# Altium schematic sheet Sheet1.SchDoc(Sheet1)
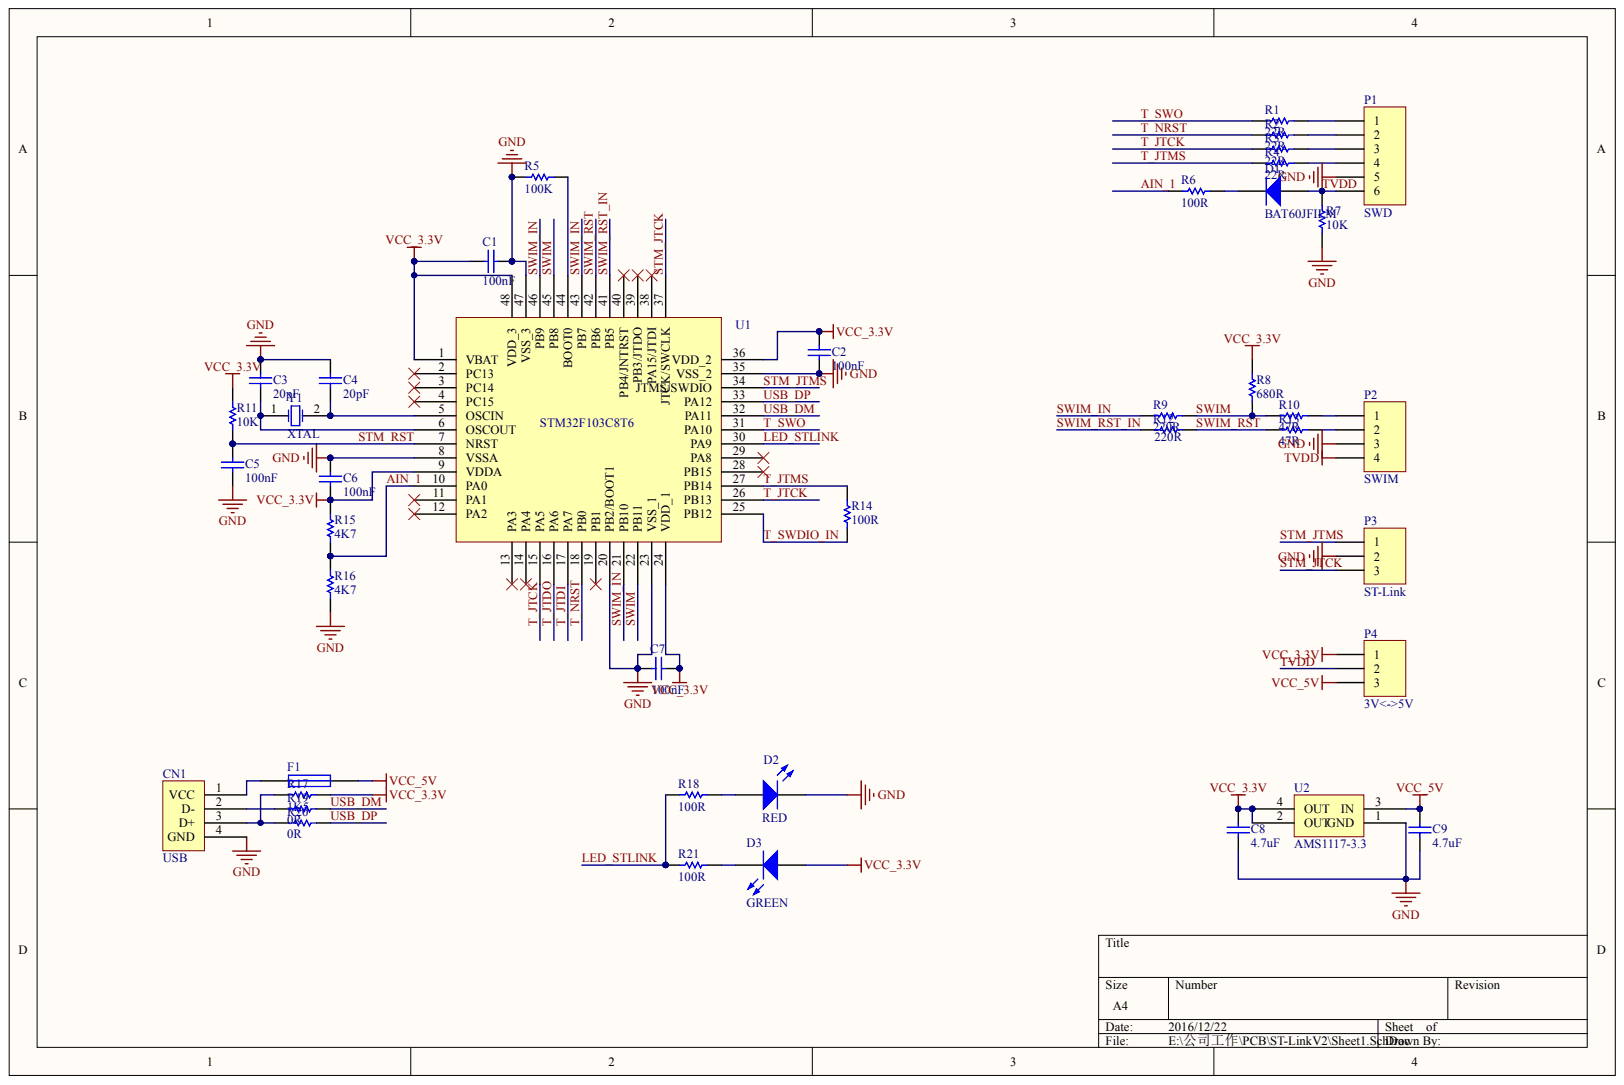
[diagram p0: Sheet1.SchDoc]

## Parts
C1  value 100nF  (hidden comment: Cap)
C2  value 100nF  (hidden comment: Cap)
C3  value 20pF  (hidden comment: Cap)
C4  value 20pF  (hidden comment: Cap)
C5  value 100nF  (hidden comment: Cap)
C6  value 100nF  (hidden comment: Cap)
C7  value 100nF  (hidden comment: Cap)
C8  value 4.7uF  (hidden comment: Cap)
C9  value 4.7uF  (hidden comment: Cap)
CN1  USB
D1  BAT60JFILM
D2  RED
D3  GREEN
F1  Fuse 1  (value not drawn)
P1  SWD
P2  SWIM
P3  ST-Link
P4  3V<->5V
R1  value 22R  (hidden comment: Res1)
R2  value 22R  (hidden comment: Res1)
R3  value 22R  (hidden comment: Res1)
R4  value 22R  (hidden comment: Res1)
R5  value 100K  (hidden comment: Res1)
R6  value 100R  (hidden comment: Res1)
R7  value 10K  (hidden comment: Res1)
R8  value 680R  (hidden comment: Res1)
R9  value 220R  (hidden comment: Res1)
R10  value 47R  (hidden comment: Res1)
R11  value 10K  (hidden comment: Res1)
R12  value 220R  (hidden comment: Res1)
R13  value 47R  (hidden comment: Res1)
R14  value 100R  (hidden comment: Res1)
R15  value 4K7  (hidden comment: Res1)
R16  value 4K7  (hidden comment: Res1)
R17  value 1K5  (hidden comment: Res1)
R18  value 100R  (hidden comment: Res1)
R19  value 0R  (hidden comment: Res1)
R20  value 0R  (hidden comment: Res1)
R21  value 100R  (hidden comment: Res1)
U1  STM32F103C8T6
U2  AMS1117-3.3
Y1  XTAL

## Nets
GND: C1.2 C2.1 C3.2 C4.2 C5.1 C6.1 C7.2 C8.1 C9.1 CN1.4(GND) D2.2 P1.5 P2.3 P3.2 R5.1 R7.1 R16.1 U1.8(VSSA) U1.20(PB2/BOOT1) U1.23(VSS_1) U1.35(VSS_2) U1.47(VSS_3) U2.1(GND)
VCC_3.3V: C1.1 C2.2 C6.2 C7.1 C8.2 D3.1 P4.1 R8.2 R11.2 R15.2 R17.2 U1.1(VBAT) U1.9(VDDA) U1.24(VDD_1) U1.36(VDD_2) U1.48(VDD_3) U2.2(OUT) U2.4(OUT)
VCC_5V: C9.2 F1.2 P4.3 U2.3(IN)
AIN_1: R6.1 R15.1 R16.2 U1.10(PA0)
LED_STLINK: R18.1 R21.1 U1.30(PA9)
STM_JTCK: P3.3 U1.37(JTCK/SWCLK)
STM_JTMS: P3.1 U1.34(JTMS/SWDIO)
STM_RST: C5.2 R11.1 U1.7(NRST)
SWIM: R8.1 R9.2 R10.1 U1.22(PB11) U1.45(PB8)
SWIM_IN: R9.1 U1.21(PB10) U1.43(PB7) U1.46(PB9)
SWIM_RST: R12.2 R13.1 U1.42(PB6)
SWIM_RST_IN: R12.1 U1.41(PB5)
T_JTCK: R3.1 U1.15(PA5) U1.26(PB13)
T_JTDI: U1.17(PA7)
T_JTDO: U1.16(PA6)
T_JTMS: R4.1 R14.2 U1.27(PB14)
T_NRST: R2.1 U1.18(PB0)
T_SWDIO_IN: R14.1 U1.25(PB12)
T_SWO: R1.1 U1.31(PA10)
TVDD: D1.1 P1.6 P2.4 P4.2 R7.2
USB_DM: R19.2 U1.32(PA11)
USB_DP: R20.2 U1.33(PA12)
NetC3_1: C3.1 U1.6(OSCOUT) Y1.1
NetC4_1: C4.1 U1.5(OSCIN) Y1.2
NetCN1_1: CN1.1(VCC) F1.1
NetCN1_2: CN1.2(D-) R19.1
NetCN1_3: CN1.3(D+) R17.1 R20.1
NetD1_2: D1.2 R6.2
NetD2_1: D2.1 R18.2
NetD3_2: D3.2 R21.2
NetP1_1: P1.1 R1.2
NetP1_2: P1.2 R2.2
NetP1_3: P1.3 R3.2
NetP1_4: P1.4 R4.2
NetP2_1: P2.1 R10.2
NetP2_2: P2.2 R13.2
NetR5_2: R5.2 U1.44(BOOT0)

Not connected: U1 2(PC13) 3(PC14) 4(PC15) 11(PA1) 12(PA2) 13(PA3) 14(PA4) 19(PB1) 28(PB15) 29(PA8) 38(PA15/JTDI) 39(PB3/JTDO) 40(PB4/JNTRST)

## Library data of the parts
C1-C9 CN1 D1-D3 F1 P1-P4 R1-R21 U1 U2 Y1 = (no symbol name)
  C1-C9: Published: 8-Jun-2000; LatestRevisionDate: 17-Jul-2002; LatestRevisionNote: Re-released for DXP Platform.; PackageReference: RAD-0.3; PackageDescription: Radial Cap, Thru-Hole; 2 Leads; 0.3 in Pin Spacing
  D1: Published: 8-Jun-2000; LatestRevisionDate: 17-Jul-2002; LatestRevisionNote: Re-released for DXP Platform.; PackageVersion: Sep-1996; PackageReference: SMC; PackageDescription: DO-214-AB/SMC; 2 C-Bend Leads; Body 7.9 x 5.9 mm, inc. leads (LxW); Code_JEDEC: DO-214-AB
  D2 D3: Published: 8-Jun-2000; LatestRevisionDate: 08-Jul-2005; LatestRevisionNote: Mech Layer 1 removed.; PackageReference: LED-0; PackageDescription: LED; 2 Leads
  F1: Published: 8-Jun-2000; LatestRevisionDate: 17-Jul-2002; LatestRevisionNote: Re-released for DXP Platform.; PackageReference: PIN-W2/E2.8; PackageDescription: Thru-Hole; 2 Leads
  P1-P4: LatestRevisionDate: 17-Jul-2002; LatestRevisionNote: Re-released for DXP Platform.
  R1-R21: Published: 8-Jun-2000; LatestRevisionDate: 17-Jul-2002; LatestRevisionNote: Re-released for DXP Platform.; PackageReference: AXIAL-0.3; PackageDescription: Axial Device, Thru-Hole; 2 Leads; 0.3 in Pin Spacing
  Y1: Published: 8-Jun-2000; LatestRevisionDate: 17-Jul-2002; LatestRevisionNote: Re-released for DXP Platform.; PackageReference: R38; PackageDescription: Crystal, Thru-Hole; 2 Leads; Body 3.1 x 8.2 mm (Dia.xH)
  C1-C9 D1-D3 F1 P1-P4 R1-R21 Y1: Publisher: Altium Limited
